# Altium schematic sheet GatewayLite.SchDoc
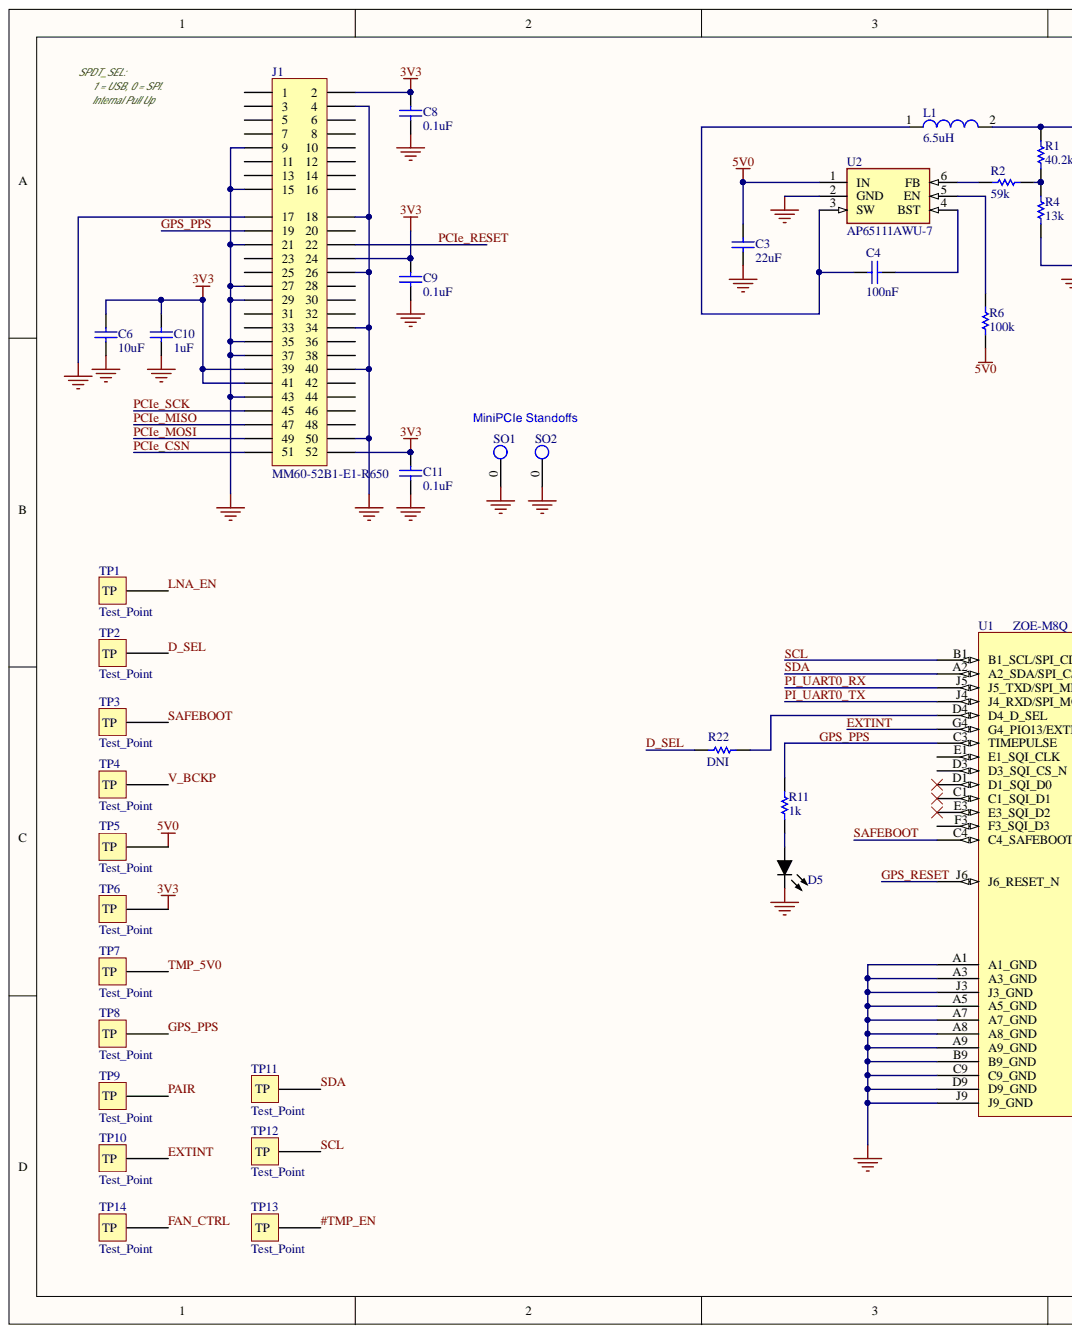
[diagram p0: GatewayLite.SchDoc, left]
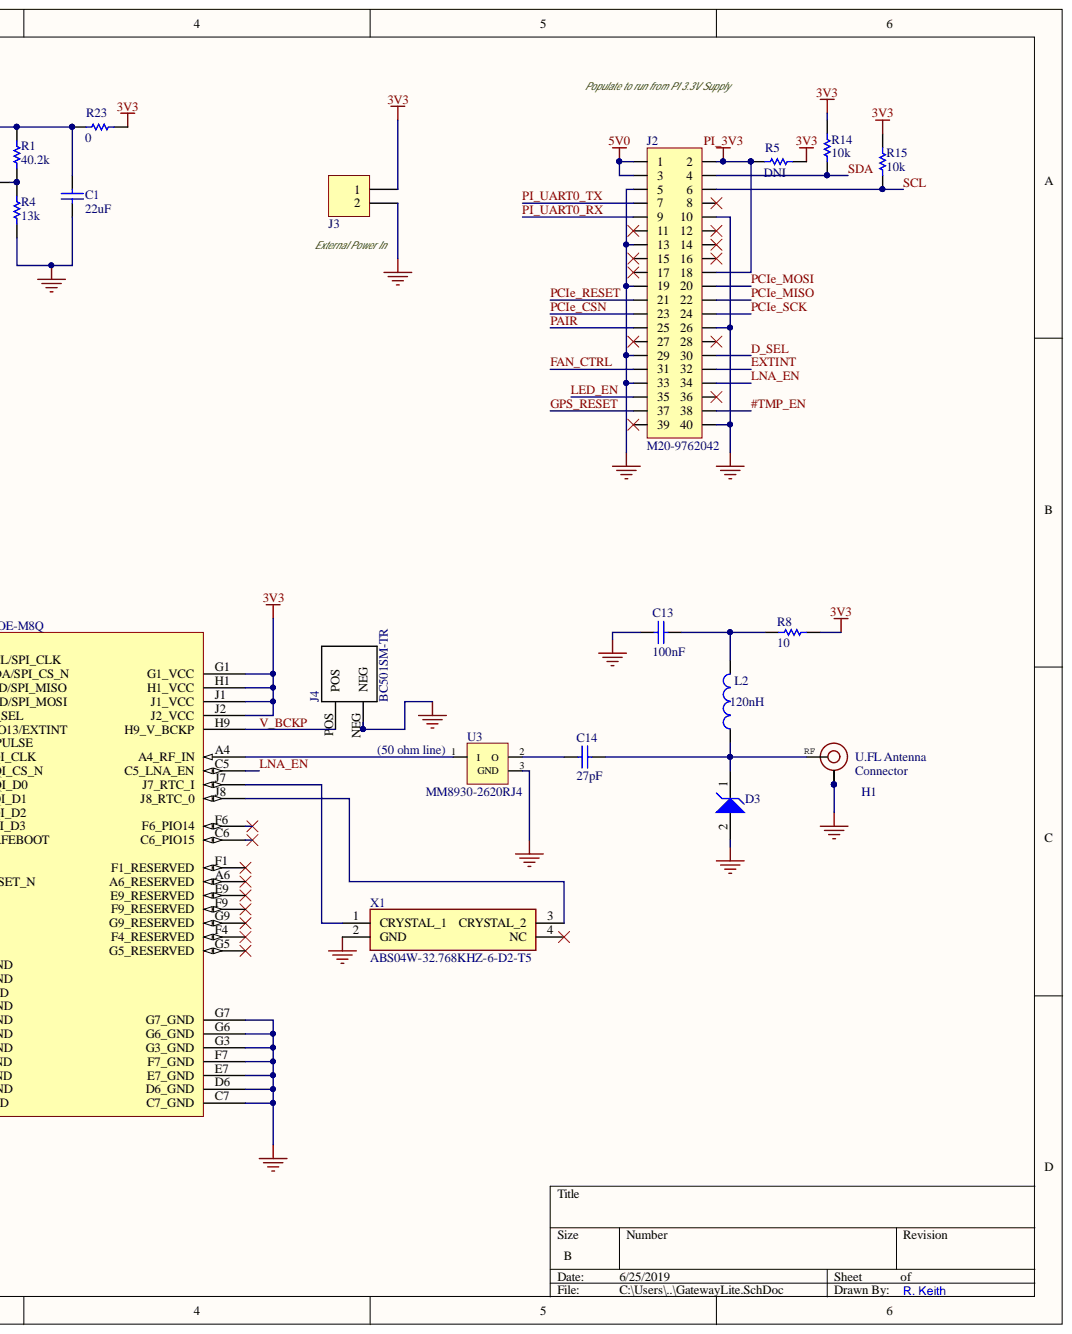
[diagram p1: GatewayLite.SchDoc, right]

## Parts
C1  22uF  [0402]
C3  22uF  [0402]
C4  100nF  [0402]
C6  10uF  [0402]
C8  0.1uF  [0805_24m]
C9  0.1uF  [0805_24m]
C10  1uF  [0805_24m]
C11  0.1uF  [0805_24m]
C13  100nF  [0201C]
C14  27pF  [0201C]
D3  PESD5V0R1BSFYL  [201]  (value not drawn)
D5  LED BLUE DIFFUSED 0603 SMD  [0603 LED]  (value not drawn)
H1  U.FL Connector 0734120110  [U.FL Connector]  (value not drawn)
J1  MM60-52B1-E1-R650  [PCB-pg6mn2ly91ah9pad3zk7-1]
J2  M20-9762042  [HDRV40W64P254_2X20_5080X508X838P]
J3  Harwin M20-9990245  [PCB-exg861sgm8y1qikelj7a-1]  (value not drawn)
J4  BC501SM-TR  [*MPD_BC501SM-TR_BC501SM-TR(Primary)]
L1  6.5uH  [WE-HCI-7050]
L2  120nH  [0201L]
R1  40.2k  [0603R]
R2  59k  [0603R]
R4  13k  [0603R]
R5  DNI  [0805_24m]
R6  100k  [0603R]
R8  10  [RESC1005X35X25NL10T10]
R11  1k  [0805_24m]
R14  10k  [0805_24m]
R15  10k  [0805_24m]
R22  DNI  [0805_24m]
R23  0  [0805_24m]
SO1  JAE Electronics NT4R1600  [PCB-a9tahyubvobjdsf2looh-1]  (value not drawn)
SO2  JAE Electronics NT4R1600  [PCB-a9tahyubvobjdsf2looh-1]  (value not drawn)
TP1  Test_Point  [Test_Point]
TP2  Test_Point  [Test_Point]
TP3  Test_Point  [Test_Point]
TP4  Test_Point  [Test_Point]
TP5  Test_Point  [Test_Point]
TP6  Test_Point  [Test_Point]
TP7  Test_Point  [Test_Point]
TP8  Test_Point  [Test_Point]
TP9  Test_Point  [Test_Point]
TP10  Test_Point  [Test_Point]
TP11  Test_Point  [Test_Point]
TP12  Test_Point  [Test_Point]
TP13  Test_Point  [Test_Point]
TP14  Test_Point  [Test_Point]
U1  ZOE-M8Q  [*ZOE-M8_ZOE-M8Q(Primary)]
U2  AP65111AWU-7  [SOT95P280X100-6N]
U3  MM8930-2620RJ4  [MM8930-2620]
X1  ABS04W-32.768KHZ-6-D2-T5  [ABS04W32768KHZ6D2T5]

## Nets
3V3: C6.2 C8.2 C9.2 C10.2 C11.2 J1.2 J1.24 J1.39 J1.41 J1.52 J3.1 R5.2 R8.2 R14.2 R15.2 R23.2 TP6.1 U1.G1(G1_VCC) U1.H1(H1_VCC) U1.J1(J1_VCC) U1.J2(J2_VCC)
5V0: C3.1 J2.1 J2.3 R6.1 TP5.1 U2.1(IN)
GND: C1.2 C3.2 C6.1 C8.1 C9.1 C10.1 C11.1 C13.2 D3.2 D5.2 H1.GND1 H1.GND2 H1.GND3 J1.4 J1.9 J1.15 J1.17 J1.18 J1.21 J1.26 J1.27 J1.29 J1.34 J1.35 J1.37 J1.40 J1.43 J1.50 J2.5 J2.10 J2.13 J2.19 J2.26 J2.29 J2.33 J2.40 J3.2 J4.NEG R4.1 SO1.0 SO2.0 U1.A1(A1_GND) U1.A3(A3_GND) U1.A5(A5_GND) U1.A7(A7_GND) U1.A8(A8_GND) U1.A9(A9_GND) U1.B9(B9_GND) U1.C7(C7_GND) U1.C9(C9_GND) U1.D6(D6_GND) U1.D9(D9_GND) U1.E7(E7_GND) U1.F7(F7_GND) U1.G3(G3_GND) U1.G6(G6_GND) U1.G7(G7_GND) U1.J3(J3_GND) U1.J9(J9_GND) U2.2(GND) U3.3 X1.2(GND)
PI_3V3: J2.2 J2.18 R5.1
#TMP_EN: J2.38 TP13.1
D_SEL: J2.30 R22.1 TP2.1
EXTINT: J2.32 TP10.1 U1.G4(G4_PIO13/EXTINT)
FAN_CTRL: J2.31 TP14.1
GPS_PPS: J1.19 R11.2 TP8.1 U1.C3(TIMEPULSE)
GPS_RESET: J2.37 U1.J6(J6_RESET_N)
LED_EN: J2.35
LNA_EN: J2.34 TP1.1 U1.C5(C5_LNA_EN)
PAIR: J2.25 TP9.1
PCIe_CSN: J1.51 J2.23
PCIe_MISO: J1.47 J2.22
PCIe_MOSI: J1.49 J2.20
PCIe_RESET: J1.22 J2.21
PCIe_SCK: J1.45 J2.24
PI_UART0_RX: J2.9 U1.J5(J5_TXD/SPI_MISO)
PI_UART0_TX: J2.7 U1.J4(J4_RXD/SPI_MOSI)
SAFEBOOT: TP3.1 U1.C4(C4_SAFEBOOT)
SCL: J2.6 R15.1 TP12.1 U1.B1(B1_SCL/SPI_CLK)
SDA: J2.4 R14.1 TP11.1 U1.A2(A2_SDA/SPI_CS_N)
TMP_5V0: TP7.1
V_BCKP: J4.POS TP4.1 U1.H9(H9_V_BCKP)
NetC1_1: C1.1 L1.2 R1.2 R23.1
NetC4_1: C4.1 U2.4(BST)
NetC4_2: C4.2 L1.1 U2.3(SW)
NetC13_1: C13.1 L2.2 R8.1
NetC14_1: C14.1 U3.2
NetC14_2: C14.2 D3.1 H1.RF L2.1
NetD5_1: D5.1 R11.1
NetR1_1: R1.1 R2.1 R4.2
NetR2_2: R2.2 U2.6(FB)
NetR6_2: R6.2 U2.5(EN)
NetR22_2: R22.2 U1.D4(D4_D_SEL)
NetU1_A4: U1.A4(A4_RF_IN) U3.1
NetU1_J7: U1.J7(J7_RTC_I) X1.1(CRYSTAL_1)
NetU1_J8: U1.J8(J8_RTC_0) X1.3(CRYSTAL_2)

Not connected: J1 1 3 5 6 7 8 10 11 12 13 14 16 20 23 25 28 30 31 32 33 36 38 42 44 46 48; J2 8 11 12 14 15 16 17 27 28 36 39; U1 A6(A6_RESERVED) C1(C1_SQI_D1) C6(C6_PIO15) D1(D1_SQI_D0) D3(D3_SQI_CS_N) E1(E1_SQI_CLK) E3(E3_SQI_D2) E9(E9_RESERVED) F1(F1_RESERVED) F3(F3_SQI_D3) F4(F4_RESERVED) F6(F6_PIO14) F9(F9_RESERVED) G5(G5_RESERVED) G9(G9_RESERVED); X1 4(NC)

## Library data of the parts
C1 C3 = Cap: Capacitor 22uF; Manufacturer: Samsung; Manufacturer Part Number: CL05A226MQ5N6J8; Component Kind: Standard; ComponentLink1Description: https://datasheet.ciiva.com/16199/cl05f104zo5nnnc-16199056.pdf?src-supplier=Future+Electronics; ComponentLink1URL: https://datasheet.ciiva.com/16199/cl05f104zo5nnnc-16199056.pdf?src-supplier=Future+Electronics; ComponentLink2Description: https://datasheet.ciiva.com/15195/getdatasheetpartid-358403-15195703.pdf?src-supplier=Verical; ComponentLink2URL: https://datasheet.ciiva.com/15195/getdatasheetpartid-358403-15195703.pdf?src-supplier=Verical; ComponentLink3Description: https://datasheet.ciiva.com/29198/458504-da-01-en-vs-kondensator-0402-29198386.pdf?src-supplier=Conrad; ComponentLink3URL: https://datasheet.ciiva.com/29198/458504-da-01-en-vs-kondensator-0402-29198386.pdf?src-supplier=Conrad; ComponentLink4Description: http://www.samsungsem.com/kr/support/library/product-catalog/mlcc.jsp?src-supplier=Digi-Key; ComponentLink4URL: http://www.samsungsem.com/kr/support/library/product-catalog/mlcc.jsp?src-supplier=Digi-Key; Library Name: Miscellaneous Devices.SchLib; Pricing 1: 1 = 0.56000, 10 = 0.39300, 100 = 0.25900, 500 = 0.19188, 1000 = 0.16310, 2500 = 0.15350 (USD); RoHS: No; Signal Integrity: Cap; Simulation: CAP; Stock 1: 230652; Supplier 1: Digi-Key; Supplier Part Number 1: 1276-7090-1-ND
C4 = Cap: Capacitor 10uF; Manufacturer: Samsung; Manufacturer Part Number: CL05F104ZO5NNNC; Dielectric: Y5V; Material: Ceramic; Tolerance: 80%; Voltage Rating: 16V; Capacitance: 100nF; Case/Package: 0402; Case Code (Imperial): 0402; Case Code (Metric): 1005; Component Kind: Standard; ComponentLink1Description: https://datasheet.ciiva.com/16199/cl05f104zo5nnnc-16199056.pdf?src-supplier=Future+Electronics; ComponentLink1URL: https://datasheet.ciiva.com/16199/cl05f104zo5nnnc-16199056.pdf?src-supplier=Future+Electronics; ComponentLink2Description: https://datasheet.ciiva.com/15195/getdatasheetpartid-358403-15195703.pdf?src-supplier=Verical; ComponentLink2URL: https://datasheet.ciiva.com/15195/getdatasheetpartid-358403-15195703.pdf?src-supplier=Verical; ComponentLink3Description: https://datasheet.ciiva.com/29198/458504-da-01-en-vs-kondensator-0402-29198386.pdf?src-supplier=Conrad; ComponentLink3URL: https://datasheet.ciiva.com/29198/458504-da-01-en-vs-kondensator-0402-29198386.pdf?src-supplier=Conrad; Contact Plating: Tin; Depth: 0.5mm; Height: 0.5mm; Length: 1mm; Library Name: Miscellaneous Devices.SchLib; Max Operating Temperature: 85°C; Min Operating Temperature: -30°C; Mount: Surface Mount; Packaging: Tape and Reel; Pricing 1: 1 = 0.10000, 10 = 0.01900, 100 = 0.00850, 500 = 0.00606, 1000 = 0.00476, 2500 = 0.00432, 5000 = 0.00398 (USD); Radiation Hardening: No; RoHS: Yes; Signal Integrity: Cap; Simulation: CAP; Stock 1: 9824455; Supplier 1: Digi-Key; Supplier Part Number 1: 1276-1004-1-ND; Thickness: 0.022inch; Width: 0.02inch
C6 = Cap: Capacitor 10uF; Manufacturer: Murata; Manufacturer Part Number: GRM155R60J106ME15D; Component Kind: Standard; LatestRevisionDate: 17-Jul-2002; LatestRevisionNote: Re-released for DXP Platform.; Library Name: Miscellaneous Devices.SchLib; PackageDescription: Radial Cap, Thru-Hole; 2 Leads; 0.3 in Pin Spacing; PackageReference: RAD-0.3; Published: 8-Jun-2000; Signal Integrity: Cap; Simulation: CAP; Value: 10uF; Publisher: Altium Limited
C8 C9 C11 = CAP: CAP 100nF 50V -20% to +80% 0805 (2012 Metric) Thickness 1mm SMD; Manufacturer: Yageo; Manufacturer Part Number: CC0805ZRY5V9BB104; Case-EIA: 0805; Case-Metric: 2012; Component Kind: Standard; Library Name: GatewayLite.SCHLIB; Max Thickness: 1 mm; Rated Voltage: 50 V; Technology: SMT; Tolerance: -20% to +80%; Value: 100nF
C10 = CAP: Manufacturer: AVX Interconnect / Elco; Manufacturer Part Number: 08053D105KAT2A; Component Kind: Standard; Library Name: GatewayLite.SCHLIB; Part Number: 08053D105KAT2A
C13 = Capacitor, SMD: Capacitor, 0201 SMD; Manufacturer: Murata; Manufacturer Part Number: GRM033R61A104KE15D; Component Kind: Standard; Manufacturer PN: GRM033R61A104KE15D; Supplier: DigiKey; Library Name: LOEC.SchLib
C14 = CAP: Manufacturer: Murata; Manufacturer Part Number: GRM0335C1E270JA01D; Component Kind: Standard; Library Name: Gateway X Project.IntLib; Part Number: GRM0335C1E270JA01D
D3 = CMP-1063-00117-1: Manufacturer: Nexperia; Component Kind: Standard; ComponentLink1Description: Manufacturer URL; ComponentLink1URL: http://www.onsemi.com/; ComponentLink2Description: Datasheet; ComponentLink2URL: http://www.onsemi.com/pub_link/Collateral/ESD9R3.3S-D.PDF; ComponentLink3Description: Package Specification; ComponentLink3URL: http://www.onsemi.com/pub_link/Collateral/514AB.PDF; ComponentLink4Description: https://www.mouser.com/ds/2/302/PESD5V0R1BSF-559339.pdf?src-supplier=Mouser; ComponentLink4URL: https://www.mouser.com/ds/2/302/PESD5V0R1BSF-559339.pdf?src-supplier=Mouser; Manufacturer Part Number: PESD5V0R1BSFYL; Pricing 1: 1 = 0.38000, 10 = 0.28900, 100 = 0.18010, 500 = 0.12322, 1000 = 0.09478 (USD); RoHS: No; Stock 1: 61777; Supplier 1: Digi-Key; Supplier Part Number 1: 1727-2173-1-ND; Library Name: RAK833 LoRaWAN Concentrator Hat.SCHLIB
D5 = LED 0603: Manufacturer: Osram; Manufacturer Part Number: LB Q39G-L2OO-35-1; Component Kind: Standard; Description: LED 0603; Manufacturer PN: LB Q39G-L2OO-35-1; Supplier: Digikey; Supplier PN: 475-2816-1-ND; Library Name: LOEC.SchLib
H1 = U.FL Connector: Manufacturer: Molex; Component Kind: Standard; Description: U.FL Connector; Manufacturer Part Number: 0734120110; Manufacturer PN: 0734120110; Supplier: Digikey; Supplier PN: WM5587CT-ND; Library Name: Starman.SchLib
J1 = MM60-52B1-E1-R650: MM60 Express Mini Card Edge Connector 52 Pos SMD, 5.9mm , 650 pc reel; Manufacturer: JAE Electronics; Component Kind: Standard; Manufacturer Part Number: MM60-52B1-E1-R650; Library Name: RAK833 LoRaWAN Concentrator Hat.SCHLIB
J2 = CONN_2x20 MALE: Headers & Wire Housings 20+20 DIL VERTICAL SOCKET TIN; Manufacturer: Harwin; Connector Type: Male Pin; Current Rating: 3A; Gender: Male; Number of Contacts: 40; Pitch: 2.54mm; Row Spacing: 0.1inch; Voltage Rating: 750V; Color: Black; Component Kind: Standard; ComponentLink1Description: https://datasheet.ciiva.com/6815/m20-976-6815078.pdf?src-supplier=Digi-Key; ComponentLink1URL: https://datasheet.ciiva.com/6815/m20-976-6815078.pdf?src-supplier=Digi-Key; Contact Mating Length: 0.228inch; Contact Plating: Gold; Housing Material: Nylon; Library Name: GatewayLite.SCHLIB; Manufacturer Part Number: M20-9762042; Mount: Through Hole; Number of Positions: 40; Number of Rows: 2; Package Quantity: 100; Packaging: Bulk; Pricing 1: 1 = 1.32000, 10 = 1.16300, 100 = 1.00320, 500 = 0.82080, 1000 = 0.72960, 5000 = 0.63840, 10000 = 0.60648 (USD); Radiation Hardening: No; RoHS: Yes; Stock 1: 883; Supplier 1: Digi-Key; Supplier Part Number 1: 952-1895-ND; Termination: Solder
J3 = SYM-3d0af4527cfce2cd-3: Board-To-Board Connector, M20 Series, Through Hole, Header, 2, 2.54 mm, Gold Plated Contacts; Contact Resistance: 25.0 mΩ; Number of Mating Cycles: 300; Voltage Rating (AC): 750 V; Component Kind: Standard; Manufacturer: Harwin; Manufacturer Part Number: M20-9990245; OctopartID: 13d0daa8641bcc17; Operating Temperature: -40.0 °C (min); Library Name: RAK833 LoRaWAN Concentrator Hat.SCHLIB
J4 = BC501SM-TR: Holder Coin Cell For Cr1220 Smd; Manufacturer: Memory Protection Devices; MF: MPD _Memory; Availability: Unavailable; Component Kind: Standard; Manufacturer Part Number: BC501SM-TR; MP: BC501SM-TR; Package: DFN-8 MPD; Price: None; SnapEDA_Link: https://www.snapeda.com/parts/BC501SM-TR/MPD%20%28Memory%20Protection%20Devices%29/view-part/?ref=snap; Library Name: RAK833 LoRaWAN Concentrator Hat.SCHLIB
L1 = CMP-0226-00083-1: SMD Flat Wire High Current Inductor WE-HCI, L=6.50 µH; Manufacturer: Wurth Electronik; Manufacturer Part Number: 744314650; Inductance: 6.50 ÂµH; Component Kind: Standard; ComponentLink1Description: Manufacturer Link; ComponentLink1URL: http://www.we-online.com; ComponentLink2Description: Datasheet; ComponentLink2URL: http://www.we-online.de/katalog/media/pdf/744314650.pdf; DatasheetVersion: ver 2, Jan-2008; Library Name: Altium Content Vault; PackageDescription: SMD Inductor, 2-Leads, Body 7.0 x 6.9 mm; PackageReference: WE-HCI-7050; PackageVersion: 2008
L2 = Inductor: Manufacturer: Murata; Manufacturer Part Number: LQP03TNR12J02D; Core Material: Non-Magnetic; Current Rating: 40mA; DC Resistance (DCR): 12R; Q Factor: 8; Self Resonant Frequency: 800MHz; Shielding: Unshielded; Test Frequency: 300MHz; Tolerance: 5%; Case/Package: 0201; Case Code (Imperial): 0201; Case Code (Metric): 0603; Component Kind: Standard; ComponentLink1Description: https://datasheet.ciiva.com/4976/product-4976380.pdf?src-supplier=Mouser; ComponentLink1URL: https://datasheet.ciiva.com/4976/product-4976380.pdf?src-supplier=Mouser; ComponentLink2Description: https://datasheet.ciiva.com/29683/s0084e-29683981.pdf?src-supplier=Digi-Key; ComponentLink2URL: https://datasheet.ciiva.com/29683/s0084e-29683981.pdf?src-supplier=Digi-Key; ComponentLink3Description: https://datasheet.ciiva.com/29683/s0084e-29683982.pdf?src-supplier=Digi-Key; ComponentLink3URL: https://datasheet.ciiva.com/29683/s0084e-29683982.pdf?src-supplier=Digi-Key; ComponentLink4Description: https://datasheet.ciiva.com/29683/s0084e-29683983.pdf?src-supplier=Digi-Key; ComponentLink4URL: https://datasheet.ciiva.com/29683/s0084e-29683983.pdf?src-supplier=Digi-Key; Composition: Thin Film; DC Current: 40mA; Depth: 0.33mm; Description: 120nH; Height: 0.012inch; Height - Seated (Max): 0.013inch; Inductance: 120nH; Lead Free: Lead Free; Length: 0.024inch; Library Name: Miscellaneous Devices.SchLib; Max DC Current: 40mA; Max Operating Temperature: 125°C; Military Standard: Not; Min Operating Temperature: -55°C; Mount: Surface Mount; Package Quantity: 15000; Packaging: Tape and Reel; Pricing 1: 1 = 0.10000, 10 = 0.04700, 100 = 0.03110, 500 = 0.02592, 1000 = 0.02178, 2500 = 0.01867 (USD); Radiation Hardening: No; RoHS: Yes; Signal Integrity: Inductor; Simulation: INDUCTOR; Stock 1: 40477; Supplier 1: Digi-Key; Supplier Part Number 1: 490-8430-1-ND; Termination: SMD/SMT; Width: 0.012inch
R1 = RES: Manufacturer: Panasonic; Manufacturer Part Number: ERA3AEB4022V; Component Kind: Standard; Library Name: GatewayLite.SCHLIB
R2 = RES: Manufacturer: Panasonic; Manufacturer Part Number: ERA3AEB5902V; Max Power Dissipation: 100mW; Resistance: 59kR; Temperature Coefficient: 25ppm/°C; Tolerance: 0.1%; Voltage Rating: 75V; Case/Package: 0603; Case Code (Imperial): 0603; Case Code (Metric): 1608; Component Kind: Standard; ComponentLink1Description: https://datasheet.ciiva.com/11810/0900766b80eb1d8c-11810293.pdf?src-supplier=RSComponents; ComponentLink1URL: https://datasheet.ciiva.com/11810/0900766b80eb1d8c-11810293.pdf?src-supplier=RSComponents; ComponentLink2Description: https://datasheet.ciiva.com/29184/452567-da-01-en-widerstand-0603-era-29184027.pdf?src-supplier=Conrad; ComponentLink2URL: https://datasheet.ciiva.com/29184/452567-da-01-en-widerstand-0603-era-29184027.pdf?src-supplier=Conrad; ComponentLink3Description: https://datasheet.ciiva.com/7937/485442-7937166.pdf?src-supplier=Element14; ComponentLink3URL: https://datasheet.ciiva.com/7937/485442-7937166.pdf?src-supplier=Element14; ComponentLink4Description: https://datasheet.ciiva.com/17209/aoa0000ce26-17209111.pdf?src-supplier=Avnet+Europe; ComponentLink4URL: https://datasheet.ciiva.com/17209/aoa0000ce26-17209111.pdf?src-supplier=Avnet+Europe; ComponentLink5Description: https://datasheet.ciiva.com/7937/485442-7937165.pdf?src-supplier=Element14; ComponentLink5URL: https://datasheet.ciiva.com/7937/485442-7937165.pdf?src-supplier=Element14; Composition: Thin Film; Contact Plating: Tin; Depth: 0.8mm; Features: Automotive AEC-Q200; Height: 0.45mm; Lead Free: Lead Free; Length: 1.6mm; Library Name: GatewayLite.SCHLIB; Max Operating Temperature: 155°C; Military Standard: Not; Min Operating Temperature: -55°C; Mount: Surface Mount; Number of Pins: 2; Number of Terminations: 2; Package Quantity: 1; Packaging: Tape and Reel; Power Rating: 100mW; Pricing 1: 1 = 0.35000, 10 = 0.30000, 100 = 0.11720, 1000 = 0.04906, 2500 = 0.04498 (USD); Radiation Hardening: No; RoHS: Yes; Stock 1: 26087; Supplier 1: Digi-Key; Supplier Part Number 1: P59KDBCT-ND; Termination: SMD/SMT; Voltage Rating (DC): 75V; Weight: 7.1E-05oz; Width: 0.8mm
R4 = RES: Manufacturer: Susumu; Manufacturer Part Number: RR0816P-133-D; Component Kind: Standard; Library Name: GatewayLite.SCHLIB
R5 R22 = RES: Manufacturer: Yageo; Part Number: RC0805FR-070RL; Component Kind: Standard; Library Name: GatewayLite.SCHLIB; Manufacturer Part Number: DNI
R6 = RES: Manufacturer: Panasonic; Manufacturer Part Number: ERA3AEB104V; Component Kind: Standard; Library Name: GatewayLite.SCHLIB
R8 = CMP-2002-02916-1: Manufacturer: Yageo; Manufacturer Part Number: RT0402BRD0710RL; Component Kind: Standard; Library Name: Altium Content Vault; Part Number: RT0402BRD0710RL
R11 = RES-2: Chip Resistor, 1 KOhm, +/- 1%, 125 mW, -55 to 155 degC, 0805 (2012 Metric), RoHS, Tape and Reel; Manufacturer: Yageo; Manufacturer Part Number: RC0805FR-071KL; Max Power Dissipation: 125mW; Resistance: 1KOhm; Temperature Coefficient: 100ppm/degreesC; Voltage Rating: 150V; Case/Package: 0805; Case Code (Imperial): 0805; Case Code (Metric): 2012; Component Kind: Standard; Composition: ThickFilm; Datasheet URL: http://www.yageo.com/NewPortal/yageodocoutput?fileName=/pdf/R-Chip/PYu-RC_Group_51_RoHS_L_5.pdf; Datasheet Version: Rev. 5, 10/2016; Depth: 1.25mm; Height: 0.024inch; Lead Free: LeadFree; Length: 0.079inch; Library Name: GatewayLite.SCHLIB; Manufacturer URL: http://www.yageo.com/; Max Operating Temperature: 155degC; Military Standard: Not; Min Operating Temperature: -55degC; Number of Pins: 2; Number of Terminations: 2; Package Description: 2-Pin Surface Mounted Device, Body 2 x 1.25 mm; Package Quantity: 1; Package Reference: 0805; Packaging: TapeandReel; Power Rating: 125mW; REACH SVHC: Unknown; RoHSCompliant: true; Termination: SMD/SMT; Tolerance: 1%; Voltage Rating (DC): 150V; Width: 0.049inch
R14 R15 = RES-2: Chip Resistor, 10 KOhm, +/- 1%, 0.125 W, -55 to 155 degC, 0805 (2012 Metric), RoHS, Tape and Reel; Manufacturer: Yageo; Manufacturer Part Number: RC0805FR-0710KL; Voltage Rating: 150 V; Component Kind: Standard; ComponentLink1Description: Manufacturer URL; ComponentLink1URL: http://www.yageo.com/; ComponentLink2Description: Datasheet; ComponentLink2URL: http://www.yageo.com/documents/recent/PYu-RC_Group_51_RoHS_L_04.pdf; DatasheetVersion: Rev. 4, 02/2016; Height: 0.5 mm; Length: 2 mm; Library Name: GatewayLite.SCHLIB; Max Operating Temperature: 155 degC; Min Operating Temperature: -55 degC; Mount: Surface Mount; Mounting Technology: Surface Mount; PackageDescription: 2-Pin Surface Mounted Device, Body 2 x 1.25 mm; Package Quantity: 5000; PackageReference: 0805; Packaging: Tape and Reel; Pins: 2; REACH SVHC: No SVHC; RoHSCompliant: true
R23 = RES: Manufacturer: Yageo; Manufacturer Part Number: RC0805FR-070RL; Component Kind: Standard; Library Name: GatewayLite.SCHLIB; Part Number: RC0805FR-070RL
SO1 SO2 = SYM-876a4f56142058ad-1: MM60 Stand Off; embossed tape 1600 pc reel; Component Kind: Standard; Manufacturer: JAE Electronics; Manufacturer Part Number: NT4R1600; OctopartID: 876a4f56142058ad; Octopart URL: https://octopart.com/nt4r1600-jae+electronics-20362796
  SO2: Library Name: GatewayLite.SCHLIB
  SO1: Library Name: RAK833 LoRaWAN Concentrator Hat.SCHLIB
TP1-TP14 = Test_Point: Rule: Fabrication Testpoint Style [Under Comp - Allow    Sides - Top, Bottom    Pref Size = 60mil    Pref Hole Size = 32mil    Using Grid = Yes    Grid = 1mil    Grid Tolerance = 0.01mil    Spacing = 50mil]; Component Kind: Standard; Description: Test_Point; Library Name: RAK833 LoRaWAN Concentrator Hat.SCHLIB
U1 = ZOE-M8Q: Fully Integrated Gnss Sip; Manufacturer: U-Blox America; Availability: Unavailable; Component Kind: Standard; Library Name: GatewayLite.SCHLIB; Manufacturer Part Number: ZOE-M8Q; Package: SMD-51 U-Blox America; Price: None; SnapEDA_Link: https://www.snapeda.com/parts/ZOE-M8B/U-Blox%20America%20Inc./view-part/?ref=snap
U2 = AP65111AWU-7: Integrated Circuit; Manufacturer: Diodes Inc.; Component Kind: Standard; Datasheet Link: https://componentsearchengine.com/Datasheets/1/AP65111AWU-7.pdf; Height: 1mm; Library Name: GatewayLite.SCHLIB; Manufacturer Part Number: AP65111AWU-7; Mouser Part Number: 621-AP65111AWU-7; Mouser Price/Stock: https://www.mouser.com/Search/Refine.aspx?Keyword=621-AP65111AWU-7
U3 = MM8930-2620RJ4: CONN SWH RCPT STR 50 OHM SMD; Manufacturer: Murata; Component Kind: Standard; Manufacturer Part Number: MM8930-2620RJ4; Manufacturer PN: MM8930-2620RJ4; Pricing 1: 1 = 0.33000, 10 = 0.26700, 50 = 0.26700, 100 = 0.20400, 1000 = 0.15300, 10000 = 0.11700 (USD); RoHS: No; Stock 1: 2475; Supplier 1: Mouser; Supplier Part Number 1: 81-MM8930-2620RJ4; Library Name: GatewayRfkLib.SchLib
X1 = ABS04W-32.768KHZ-6-D2-T5: Crystal or Oscillator; Manufacturer: ABRACON; Component Kind: Standard; Datasheet Link: https://componentsearchengine.com/Datasheets/1/ABS04W-32.768KHZ-6-D2-T5.pdf; Height: 0.35mm; Manufacturer Part Number: ABS04W-32.768KHZ-6-D2-T5; Mouser Part Number: 815-04W-32.768-6-D2T; Mouser Price/Stock: https://www.mouser.com/Search/Refine.aspx?Keyword=815-04W-32.768-6-D2T
